# Altium schematic sheet [09] - ETHERNET.SchDoc
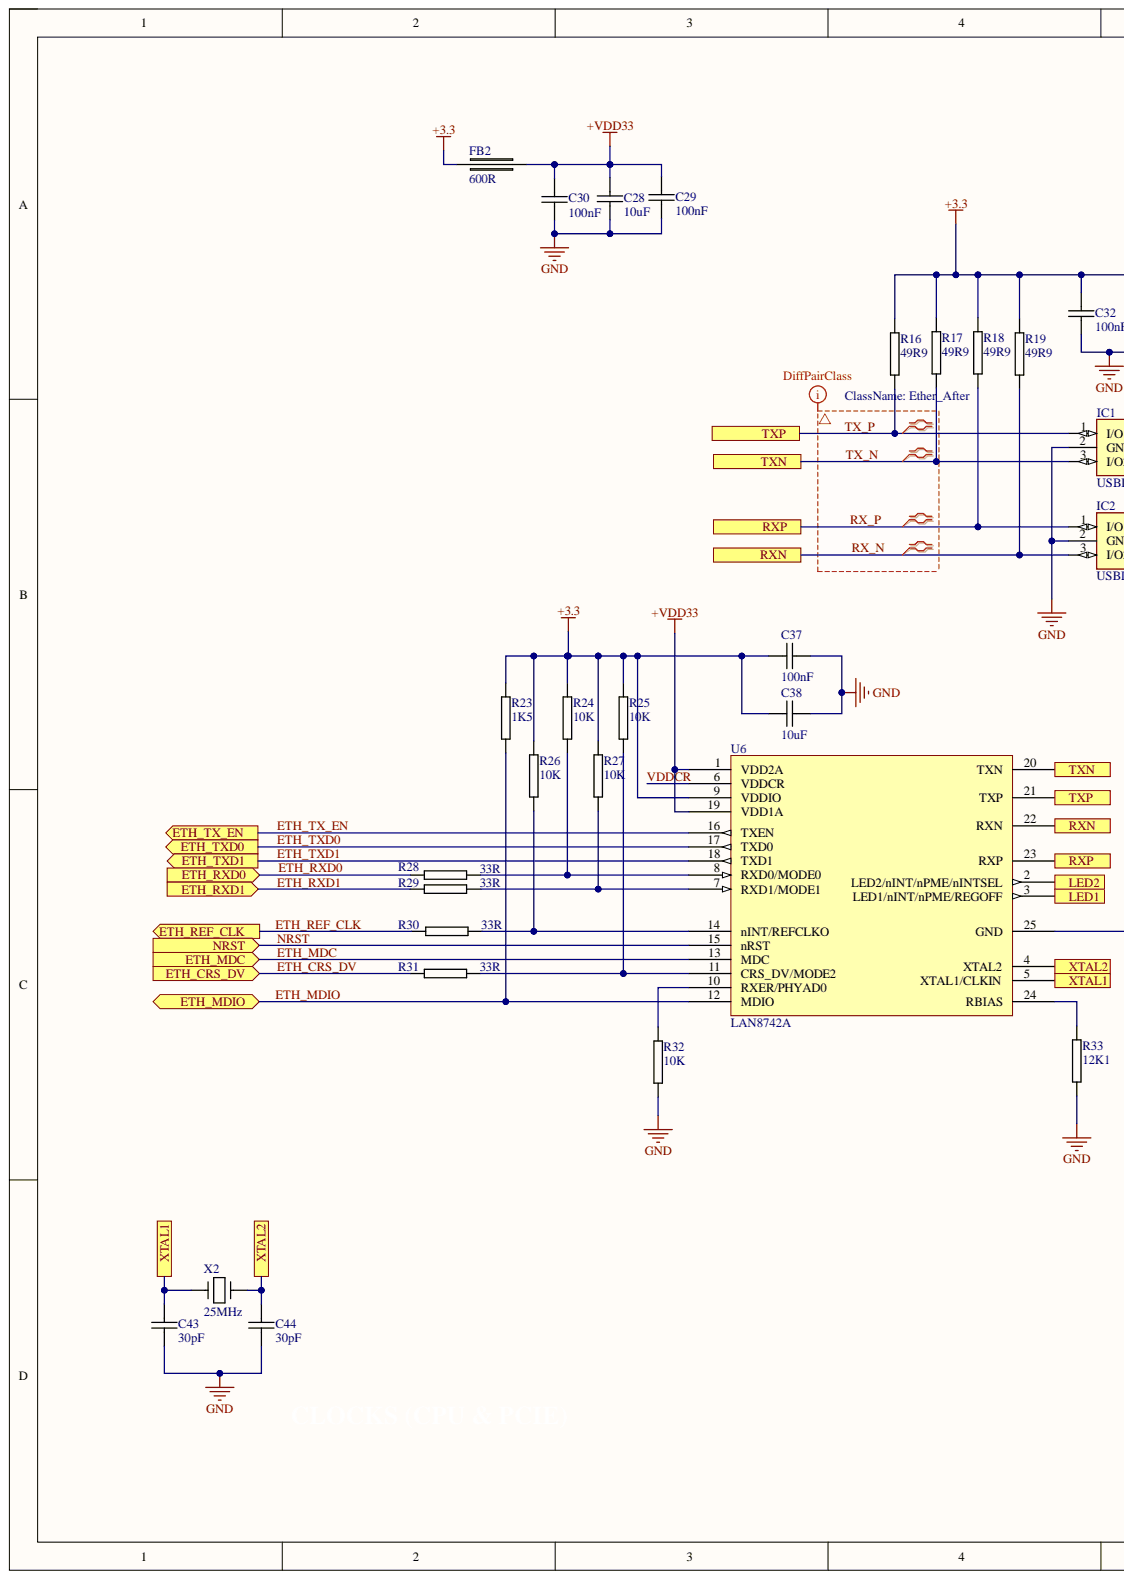
[diagram p0: [09] - ETHERNET.SchDoc, left]
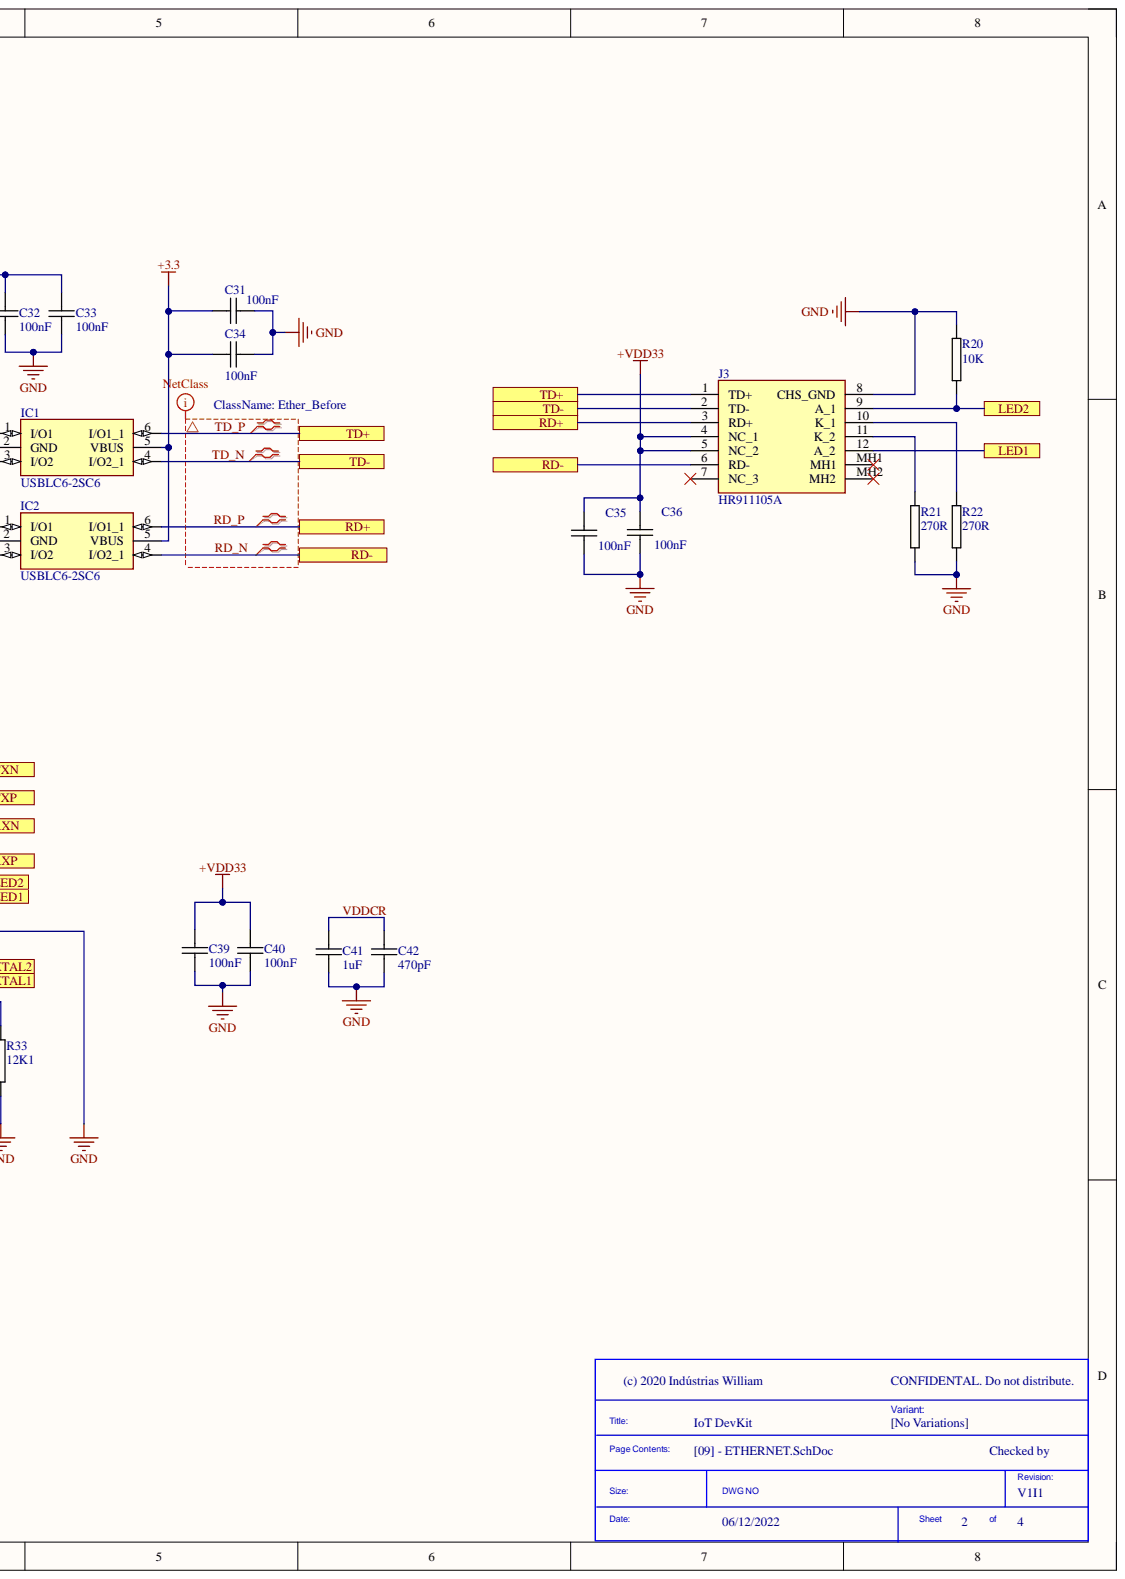
[diagram p1: [09] - ETHERNET.SchDoc, right]

## Parts
C28  10uF  [0603C-GENERIC]
C29  100nF  [0603C-GENERIC]
C30  100nF  [0603C-GENERIC]
C31  100nF  [0603C-GENERIC]
C32  100nF  [0603C-GENERIC]
C33  100nF  [0603C-GENERIC]
C34  100nF  [0603C-GENERIC]
C35  100nF  [0603C-GENERIC]
C36  100nF  [0603C-GENERIC]
C37  100nF  [0603C-GENERIC]
C38  10uF  [0603C-GENERIC]
C39  100nF  [0603C-GENERIC]
C40  100nF  [0603C-GENERIC]
C41  1uF  [0603C-GENERIC]
C42  470pF  [0603C-470P]
C43  30pF  [0603C-GENERIC]
C44  30pF  [0603C-GENERIC]
FB2  600R  [0603FB-1KZ]
IC1  USBLC6-2SC6  [SOT95P280X145-6N]
IC2  USBLC6-2SC6  [SOT95P280X145-6N]
J3  HR911105A  [HR911105A]
R16  49R9  [0603R-GENERIC]
R17  49R9  [0603R-GENERIC]
R18  49R9  [0603R-GENERIC]
R19  49R9  [0603R-GENERIC]
R20  10K  [0603R-10K]
R21  270R  [0603R-270]
R22  270R  [0603R-270]
R23  1K5  [0603R-1k5]
R24  10K  [0603R-10K]
R25  10K  [0603R-10K]
R26  10K  [0603R-10K]
R27  10K  [0603R-10K]
R28  33R  [0603R-33]
R29  33R  [0603R-33]
R30  33R  [0603R-33]
R31  33R  [0603R-33]
R32  10K  [0603R-10K]
R33  12K1  [0603R-12K]
U6  LAN8742A  [Quad24]
X2  25MHz  [5032]

## Ports
ETH_CRS_DV, ETH_MDC, ETH_MDIO, ETH_REF_CLK, ETH_RXD0, ETH_RXD1, ETH_TX_EN, ETH_TXD0, ETH_TXD1, LED1, LED2, NRST, RD+, RD-, RXN, RXP, TD+, TD-, TXN, TXP, XTAL1, XTAL2

## Nets
+3.3: C31.2 C32.2 C33.2 C34.2 C37.1 C38.2 FB2.1 IC1.5(VBUS) IC2.5(VBUS) R16.1 R17.1 R18.1 R19.1 R23.1 R24.2 R25.2 R26.2 R27.2 U6.9(VDDIO)
+VDD33: C28.1 C29.1 C30.1 C35.1 C36.1 C39.2 C40.2 FB2.2 J3.4(NC_1) J3.5(NC_2) U6.1(VDD2A) U6.19(VDD1A)
GND: C28.2 C29.2 C30.2 C31.1 C32.1 C33.1 C34.1 C35.2 C36.2 C37.2 C38.1 C39.1 C40.1 C41.2 C42.2 C43.2 C44.2 IC1.2(GND) IC2.2(GND) J3.8(CHS_GND) R20.2 R21.2 R22.2 R32.1 R33.2 U6.25(GND)
ETH_CRS_DV: R31.1 ; port ETH_CRS_DV
ETH_MDC: U6.13(MDC) ; port ETH_MDC
ETH_MDIO: R23.2 U6.12(MDIO) ; port ETH_MDIO
ETH_REF_CLK: R30.1 ; port ETH_REF_CLK
ETH_RXD0: R28.1 ; port ETH_RXD0
ETH_RXD1: R29.1 ; port ETH_RXD1
ETH_TX_EN: U6.16(TXEN) ; port ETH_TX_EN
ETH_TXD0: U6.17(TXD0) ; port ETH_TXD0
ETH_TXD1: U6.18(TXD1) ; port ETH_TXD1
LED1: J3.12(A_2) U6.3(LED1/nINT/nPME/REGOFF) ; port LED1
LED2: J3.9(A_1) R20.1 U6.2(LED2/nINT/nPME/nINTSEL) ; port LED2
NRST: U6.15(nRST) ; port NRST
RD_N: IC2.4(I/O2_1) J3.6(RD-)
RD_P: IC2.6(I/O1_1) J3.3(RD+)
RX_N: IC2.3(I/O2) R19.2 U6.22(RXN) ; port RXN
RX_P: IC2.1(I/O1) R18.2 U6.23(RXP) ; port RXP
TD_N: IC1.4(I/O2_1) J3.2(TD-)
TD_P: IC1.6(I/O1_1) J3.1(TD+)
TX_N: IC1.3(I/O2) R17.2 U6.20(TXN) ; port TXN
TX_P: IC1.1(I/O1) R16.2 U6.21(TXP) ; port TXP
VDDCR: C41.1 C42.1 U6.6(VDDCR)
XTAL1: C43.1 U6.5(XTAL1/CLKIN) X2.1 ; port XTAL1
XTAL2: C44.1 U6.4(XTAL2) X2.2 ; port XTAL2
NetJ3_10: J3.10(K_1) R22.1
NetJ3_11: J3.11(K_2) R21.1
NetR24_1: R24.1 R28.2 U6.8(RXD0/MODE0)
NetR25_1: R25.1 R31.2 U6.11(CRS_DV/MODE2)
NetR26_1: R26.1 R30.2 U6.14(nINT/REFCLKO)
NetR27_1: R27.1 R29.2 U6.7(RXD1/MODE1)
NetR32_2: R32.2 U6.10(RXER/PHYAD0)
NetR33_1: R33.1 U6.24(RBIAS)

Not connected: J3 7(NC_3) MH1 MH2

## Library data of the parts
C28 C38 = 0603C-10UF-6V3-X5R-MUR: CAPACITOR 0603 CERAMIC; Manufacturer: Murata Electronics North America; Manufacturer Part Number: GRM188R60J106ME47D; Reel: C24; Component Kind: Standard; Library Name: vh_altium_library.intLib; Supplier 1: Digi-Key; Supplier Part Number 1: 490-3896-2-ND
C29-C37 C39 C40 = 0603C-100NF-25V-X7R-TDK: CAPACITOR 0603 CERAMIC; Manufacturer: TDK Corporation; Manufacturer Part Number: C1608X7R1E104K; Reel: C12; Component Kind: Standard; Library Name: vh_altium_library.intLib; Supplier 1: Digi-Key; Supplier Part Number 1: 445-1316-2-ND
C41 = 0603C-1UF-10V-X5R-TDK: CAPACITOR 0603 CERAMIC; Manufacturer: TDK Corporation; Manufacturer Part Number: C1608X5R1A105K; Reel: C23; Component Kind: Standard; Library Name: vh_altium_library.intLib; Supplier 1: Digi-Key; Supplier Part Number 1: 445-1321-2-ND
C42 = 0603C-470PF-50V-NP0: CAPACITOR 0603 CERAMIC; Manufacturer: AVX Corporation; Manufacturer Part Number: 06035C471KAT2A; Reel: C66; Component Kind: Standard; Library Name: vh_altium_library.intLib; Supplier 1: Digi-Key; Supplier Part Number 1: 478-1211-2-ND
C43 C44 = 0603C-22PF-50V-C0G-PAN: CAPACITOR 0603 CERAMIC; Manufacturer: Panasonic - ECG; Manufacturer Part Number: ECJ-1VC1H220J; Capacitance: 22pF; Reel: C10; Temperature Coefficient: C0G, NP0; Tolerance: ±5%; Voltage - Rated: 50V; Category: Capacitors; Component Kind: Standard; Features: General Purpose; Lead Spacing: -; Library Name: vh_altium_library.intLib; Mounting Type: Surface Mount, MLCC; Operating Temperature: -55°C ~ 125°C; Package / Case: 0603 (1608 metric); Packaging: Tape & Reel (TR); RoHS: RoHS Compliant; Supplier: Digi-Key; Supplier 1: Digi-Key; Supplier Part Number: PCC220ACVTR-ND; Supplier Part Number 1: PCC220ACVTR-ND; Thickness: 0.80mm
FB2 = 0603FB-1KZ: FERRITE BEAD 1000 OHM 400mA; Manufacturer: TDK Corporation; Manufacturer Part Number: MMZ1608R102A; Reel: F1; Component Kind: Standard; Library Name: vh_altium_library.intLib; Supplier 1: Digi-Key; Supplier Part Number 1: 445-1548-2-ND
IC1 IC2 = USBLC6-2SC6: Integrated Circuit; Manufacturer_Name: STMicroelectronics; Allied_Number: 70389689; Allied Price/Stock: http://www.alliedelec.com/stmicroelectronics-usblc6-2sc6/70389689/; Component Kind: Standard; Datasheet Link: http://www.st.com/st-web-ui/static/active/en/resource/technical/document/datasheet/CD00050750.pdf; Height: 1.45mm; Manufacturer_Part_Number: USBLC6-2SC6; Mouser Part Number: 511-USBLC6-2SC6; Mouser Price/Stock: https://www.mouser.co.uk/ProductDetail/STMicroelectronics/USBLC6-2SC6?qs=po45yt2pPpu%2FhNIlwQdTlg%3D%3D; RS Part Number: 6247693P; RS Price/Stock: http://uk.rs-online.com/web/p/products/6247693P; Library Name: Componentes Deived Projetos.IntLib
J3 = HR911105A: Connector; Manufacturer_Name: HANRUN(Zhongshan HanRun Elec); Component Kind: Standard; Datasheet Link: https://datasheet.lcsc.com/szlcsc/Zhongshan-HanRun-Elec-HR911105A_C12074.pdf; Height: 13.75mm; Manufacturer_Part_Number: HR911105A; Library Name: Componentes Deived Projetos.IntLib
R16-R19 = 0603R-49R9: GENERIC RESISTOR 0603; Component Kind: Standard; Library Name: vh_altium_library.intLib; Supplier 1: Digi-Key; Supplier Part Number 1: RMCF0603FT49R9TR-ND
R20 R24-R27 R32 = 0603R-10K: GENERIC RESISTOR 0603; Manufacturer: Stackpole Electronics Inc; Manufacturer Part Number: RMCF 1/16 10K 1% R; Power (Watts): 0.1W, 1/10W; Reel: R21; Resistance In Ohms: 10.0K; Temperature Coefficient: ±100ppm/°C; Tolerance: ±1%; Case: 0603 (1608 metric); Category: Resistors; Component Kind: Standard; Composition: Thick Film; Lead Style: Surface Mount (SMD - SMT); Library Name: vh_altium_library.intLib; Packaging: Digi-Reel®; RoHS: RoHS Compliant; Supplier: Digi-Key; Supplier 1: Digi-Key; Supplier Part Number: RMCF1/1610KFRDKR-ND; Supplier Part Number 1: RMCF0603FT10K0DKR-ND
R21 R22 = 0603R-270: GENERIC RESISTOR 0603; Manufacturer: Stackpole Electronics Inc; Manufacturer Part Number: RMCF 1/16 270 1% R; Power (Watts): 0.1W, 1/10W; Resistance In Ohms: 270; Temperature Coefficient: ±100ppm/°C; Tolerance: ±1%; Case: 0603 (1608 metric); Category: Resistors; Component Kind: Standard; Composition: Thick Film; Lead Style: Surface Mount (SMD - SMT); Library Name: vh_altium_library.intLib; Packaging: Tape & Reel (TR); RoHS: RoHS Compliant; Supplier: Digi-Key; Supplier 1: Digi-Key; Supplier Part Number: RMCF1/16270FR-ND; Supplier Part Number 1: RMCF1/16270FR-ND
R23 = 0603R-1K5: GENERIC RESISTOR 0603; Manufacturer: Stackpole Electronics Inc; Manufacturer Part Number: RMCF 1/16 15K 1% R; Power (Watts): 0.1W, 1/10W; Resistance In Ohms: 15.0K; Temperature Coefficient: ±100ppm/°C; Tolerance: ±1%; Case: 0603 (1608 metric); Category: Resistors; Component Kind: Standard; Composition: Thick Film; Lead Style: Surface Mount (SMD - SMT); Library Name: vh_altium_library.intLib; Packaging: Tape & Reel (TR); RoHS: RoHS Compliant; Supplier: Digi-Key; Supplier 1: Digi-Key; Supplier Part Number: RMCF1/1615KFRTR-ND; Supplier Part Number 1: RMCF1/1615KFRTR-ND
R28-R31 = 0603R-33: GENERIC RESISTOR 0603; Manufacturer: Stackpole Electronics Inc; Manufacturer Part Number: RMC 1/16 33 1% G; Power (Watts): 0.1W, 1/10W; Reel: R18; Resistance In Ohms: 33.0; Temperature Coefficient: ±100ppm/°C; Tolerance: ±1%; Case: 0603 (1608 metric); Category: Resistors; Component Kind: Standard; Composition: Thick Film; Lead Style: Surface Mount (SMD - SMT); Library Name: vh_altium_library.intLib; Packaging: Tape & Reel (TR); RoHS: RoHS Compliant; Supplier: Digi-Key; Supplier 1: Digi-Key; Supplier Part Number: RMC1/1633FG-ND; Supplier Part Number 1: RMCF0603FT33R0TR-ND
R33 = 0603R-12K: GENERIC RESISTOR 0603; Manufacturer: Stackpole Electronics Inc; Manufacturer Part Number: RMCF 1/16 12K 1% R; Power (Watts): 0.1W, 1/10W; Resistance In Ohms: 12.0K; Temperature Coefficient: ±100ppm/°C; Tolerance: ±1%; Case: 0603 (1608 metric); Category: Resistors; Component Kind: Standard; Composition: Thick Film; Lead Style: Surface Mount (SMD - SMT); Library Name: vh_altium_library.intLib; Packaging: Cut Tape (CT); RoHS: RoHS Compliant; Supplier: Digi-Key; Supplier 1: Digi-Key; Supplier Part Number: RMCF1/1612KFRCT-ND; Supplier Part Number 1: RMCF1/1612KFRCT-ND
X2 = 16MC-HC49US: 16MHZ CRSYTAL HC49US; Component Kind: Standard; Library Name: vh_altium_library.intLib; Supplier 1: Mouser; Supplier Part Number 1: 815-ABLS-16.0M-T
U6 = LAN8742A: Component Kind: Standard; Library Name: Componentes Deived Projetos.IntLib
